# Altium schematic sheet Sheet1.SchDoc(Sheet1)
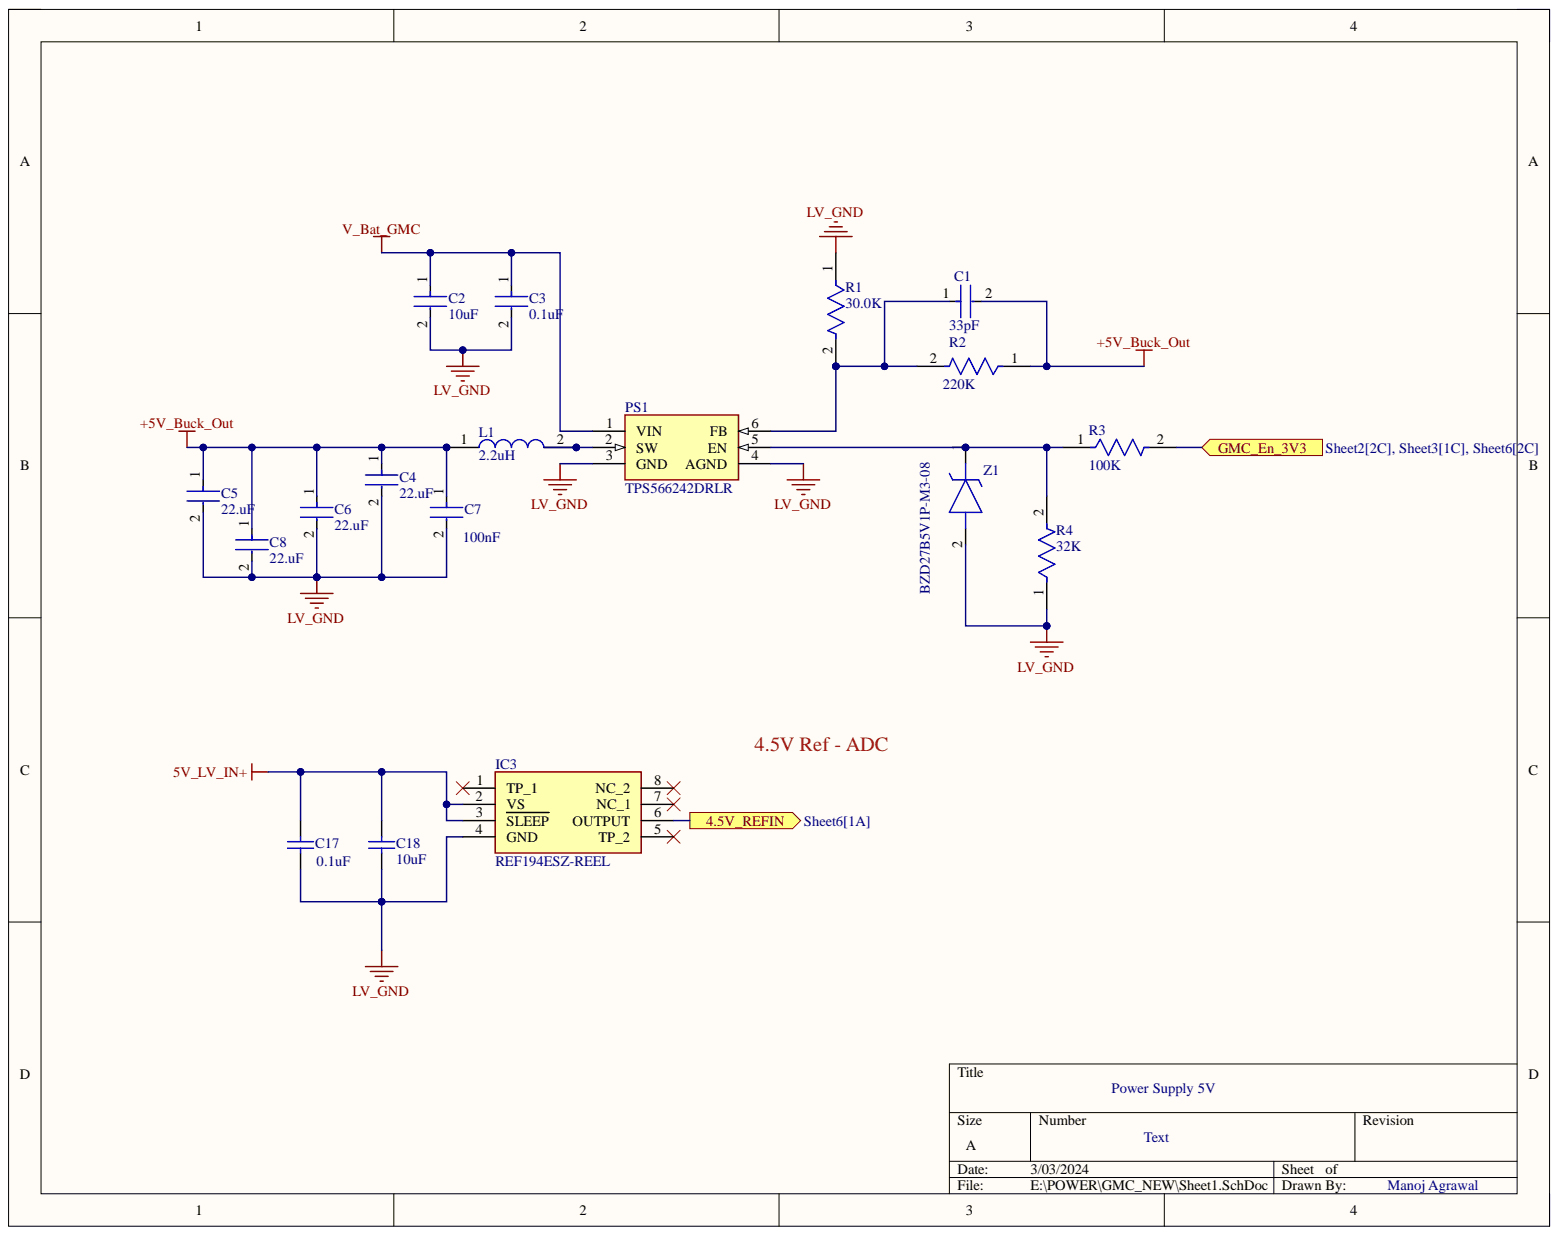
[diagram p0: Sheet1.SchDoc]

## Parts
C1  33pF  [FP-C0402-BB-MFG]
C2  10uF  [FP-CC0805-0_85-MFG]
C3  0.1uF  [FP-CC0805-0_85-MFG]
C4  22.uF  [FP-CC0805-0_85-MFG]
C5  22.uF  [FP-CC0805-0_85-MFG]
C6  22.uF  [FP-CC0805-0_85-MFG]
C7  100nF  [FP-CC0805-0_85-MFG]
C8  22.uF  [FP-CC0805-0_85-MFG]
C17  0.1uF  [CAPC2013X88X50LL20T25]
C18  10uF  [CAPC2013X88X50LL20T25]
IC3  REF194ESZ-REEL  [SOIC127P600X175-8N]
L1  2.2uH  [XGL3530101MEC]
PS1  TPS566242DRLR  [SOT50P160X60-6N]
R1  30.0K  [FP-CRCW0805-HPe3-IPC_A]
R2  220K  [FP-CRCW0805-HPe3-IPC_A]
R3  100K  [FP-CRCW0805-HPe3-IPC_A]
R4  32K  [FP-CRCW0805-HPe3-IPC_A]
Z1  BZD27B5V1P-M3-08  [SODFL3718X108N]

## Ports
4.5V_REFIN, GMC_En_3V3

## Nets
5V_LV_IN+: C1.2 C4.1 C5.1 C6.1 C7.1 C8.1 C17.2 C18.2 IC3.2(VS) IC3.3(SLEEP) L1.1 R2.1
LV_GND: C2.2 C3.2 C4.2 C5.2 C6.2 C7.2 C8.2 C17.1 C18.1 IC3.4(GND) PS1.3(GND) PS1.4(AGND) R1.1 R4.1 Z1.2
V_Bat_GMC: C2.1 C3.1 PS1.1(VIN)
4.5V_REFIN: IC3.6(OUTPUT) ; port 4.5V_REFIN
GMC_En_3V3: R3.2 ; port GMC_En_3V3
NetC1_1: C1.1 PS1.6(FB) R1.2 R2.2
NetL1_2: L1.2 PS1.2(SW)
NetPS1_5: PS1.5(EN) R3.1 R4.2 Z1.1

Not connected: IC3 1(TP_1) 5(TP_2) 7(NC_1) 8(NC_2)

## Library data of the parts
C1 = CMP-2008-02046-2: CAP CER 0.1UF 10V X5R 0402; Manufacturer: Kemet; Manufacturer Part Number: C0402C104K8PACTU; Capacitance: 0.10 μF; Material: Ceramic; Temperature Coefficient: 15%; Tolerance: 0.1; Value: 0.10 μF; Automotive: No; Category: Cap; Component Kind: Standard; ComponentLink2Description: Datasheet; ComponentLink2URL: https://content.kemet.com/datasheets/KEM_C1002_X7R_SMD.pdf; Device Class L1: Passive Components; Device Class L2: Capacitors; Device Class L3: Ceramic Capacitors; Digikey Description: CAP CER 0.1UF 10V X5R 0402; Height: 0.55mm; Ipc Land Pattern Name: CAPC10050X50; Lead Free: Yes; Library Name: Altium Content Vault; Mouser Description: Capacitor 100 nF 10 VDC 0402; Octopart: https://octopart.com/c0402c104k8pactu-kemet-140039; Package: C0402-BB; RoHS: TRUE; Temperature: -55°C to +125°C; Temperature Characteristic: X5R; Temperature Range High: +125°C; Temperature Range Low: -55°C; Voltage Rating: 10 V
C2-C8 = CMP-03422-000080-1: CAP CER 0.47UF 16V X7R 0805; Manufacturer: Yageo; Manufacturer Part Number: CC0805KKX7R7BB474; Capacitance: 470 nF; Material: Ceramic; Tolerance: 0.1; Value: 470 nF; Automotive: No; Category: Cap; Component Kind: Standard; ComponentLink2Description: Datasheet; ComponentLink2URL: http://www.yageo.com/documents/recent/UPY-GPHC_Y5V_6.3V-to-50V_8.pdf; Device Class L1: Passive Components; Device Class L2: Capacitors; Device Class L3: Ceramic Capacitors; Digikey Description: CAP CER 0.47UF 16V X7R 0805; Footprint Url: http://www.yageo.com/exep/pages/download/literatures/UPY-C_GEN_24.pdf; Height: 0.95mm; Ipc Land Pattern Name: CAPC200125X85; Lead Free: Yes; Library Name: Altium Content Vault; Mouser Description: 0805 0.47 uF 16 V ±10% Tolerance X7R SMT Multilayer Ceramic Capacitor; Octopart: https://octopart.com/cc0805kkx7r7bb474-yageo-42522863; Package: CC0805-0.85; RoHS: TRUE; Temperature: -30°C to +85°C; Temperature Characteristic: X7R; Temperature Range High: +85°C; Temperature Range Low: -30°C; Voltage Rating: 16 V
C17 C18 = CMP-2007-00266-1: Manufacturer: Kemet; Part Number: C0805C103K5RACTU; Component Kind: Standard; Library Name: Altium Content Vault
IC3 = REF194ESZ-REEL: Integrated Circuit; Manufacturer_Name: Analog Devices; Arrow Part Number: REF194ESZ-REEL; Arrow Price/Stock: https://www.arrow.com/en/products/ref194esz-reel/analog-devices?region=nac; Component Kind: Standard; Datasheet Link: https://www.analog.com/media/en/technical-documentation/data-sheets/REF19xSeries.pdf; Height: 1.75mm; Manufacturer_Part_Number: REF194ESZ-REEL; Mouser Part Number: 584-REF194ESZ-R; Mouser Price/Stock: https://www.mouser.co.uk/ProductDetail/Analog-Devices/REF194ESZ-REEL?qs=WIvQP4zGang9L0m06d%2FZGQ%3D%3D
L1 = XGL3530-101MEC: Inductor; Manufacturer_Name: COILCRAFT; Component Kind: Standard; Datasheet Link: https://www.coilcraft.com/getmedia/165f8d43-db52-4929-bde5-71cb0b0110dc/xgl3530.pdf; Height: 3mm; Manufacturer_Part_Number: XGL3530-101MEC
PS1 = TPS566242DRLR: Power Supply; Manufacturer_Name: Texas Instruments; Arrow Part Number: TPS566242DRLR; Arrow Price/Stock: https://www.arrow.com/en/products/tps566242drlr/texas-instruments?region=nac; Component Kind: Standard; Datasheet Link: https://www.ti.com/lit/ds/symlink/tps566242.pdf; Height: 0.6mm; Manufacturer_Part_Number: TPS566242DRLR; Mouser Part Number: 595-TPS566242DRLR; Mouser Price/Stock: https://www.mouser.co.uk/ProductDetail/Texas-Instruments/TPS566242DRLR?qs=t7xnP681wgXuE6iKRfU8aQ%3D%3D
R1-R4 = CMP-2001-04185-2: RES Thick Film, 0Ω, 0.5W, 0805; Max Power Dissipation: 500mW; Power Rating: 330mW; Resistance: 0mR; Temperature Coefficient: 0ppm/°C; Tolerance: 5%; Voltage Rating: 150V; Case Code (Imperial): 0805; Case Code (Metric): 2012; Case/Package: 0805; Component Kind: Standard; Composition: Thick Film; Contact Plating: Tin; Depth: 1.25mm; Features: Automotive AEC-Q200; Height: 0.024inch; Lead Free: Lead Free; Length: 2mm; Library Name: Altium Content Vault; Max Operating Temperature: 155°C; Military Standard: Not; Min Operating Temperature: -55°C; Mount: Surface Mount; Number of Pins: 2; Number of Terminations: 2; Package Quantity: 5000; Packaging: Tape and Reel; Radiation Hardening: No; REACH SVHC: No SVHC; Termination: Solder; Voltage Rating (DC): 150V; Weight: 0.000194oz; Width: 0.049inch
Z1 = BZD27B5V1P-M3-08: Zener Diode; Manufacturer_Name: Vishay; Component Kind: Standard; Datasheet Link: http://www.vishay.com/docs/85922/bzd27b-mseries.pdf; Height: 1.08mm; Manufacturer_Part_Number: BZD27B5V1P-M3-08; Mouser Part Number: 78-BZD27B5V1P-M3-08; Mouser Price/Stock: https://www.mouser.co.uk/ProductDetail/Vishay-Semiconductors/BZD27B5V1P-M3-08?qs=AfeIN4OqR0AbRy8PoogNmQ%3D%3D
